# Altium schematic sheet CAN Interface.SchDoc
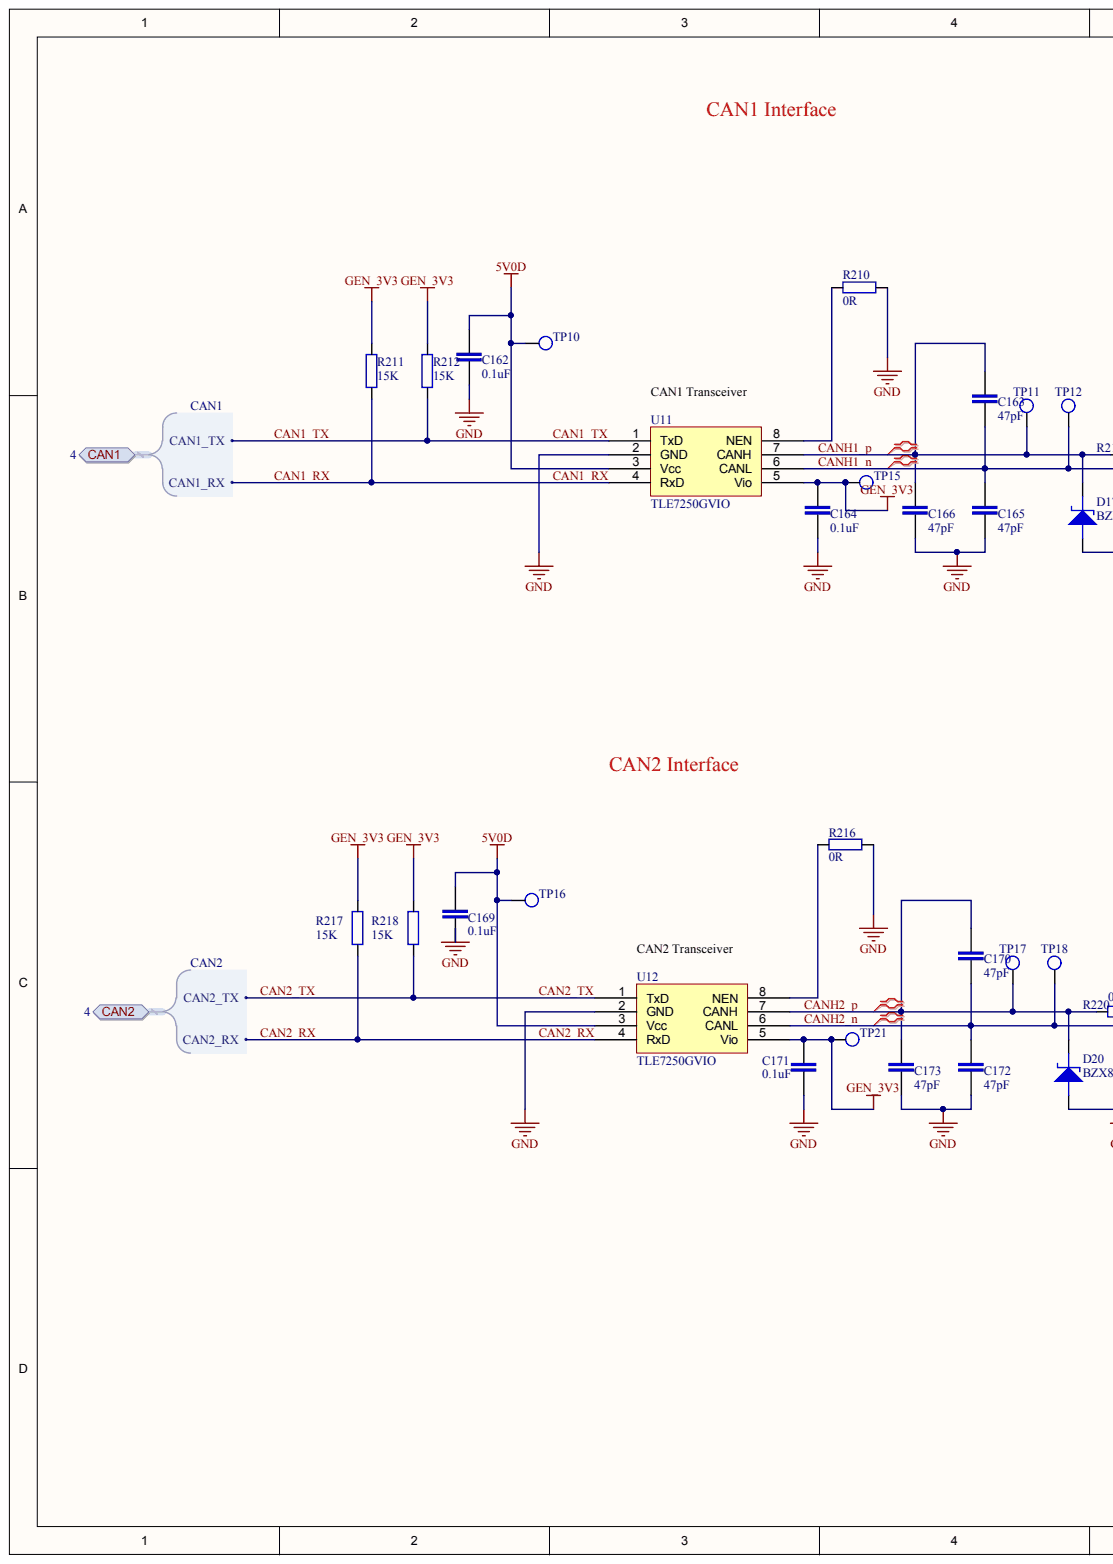
[diagram p0: CAN Interface.SchDoc, left]
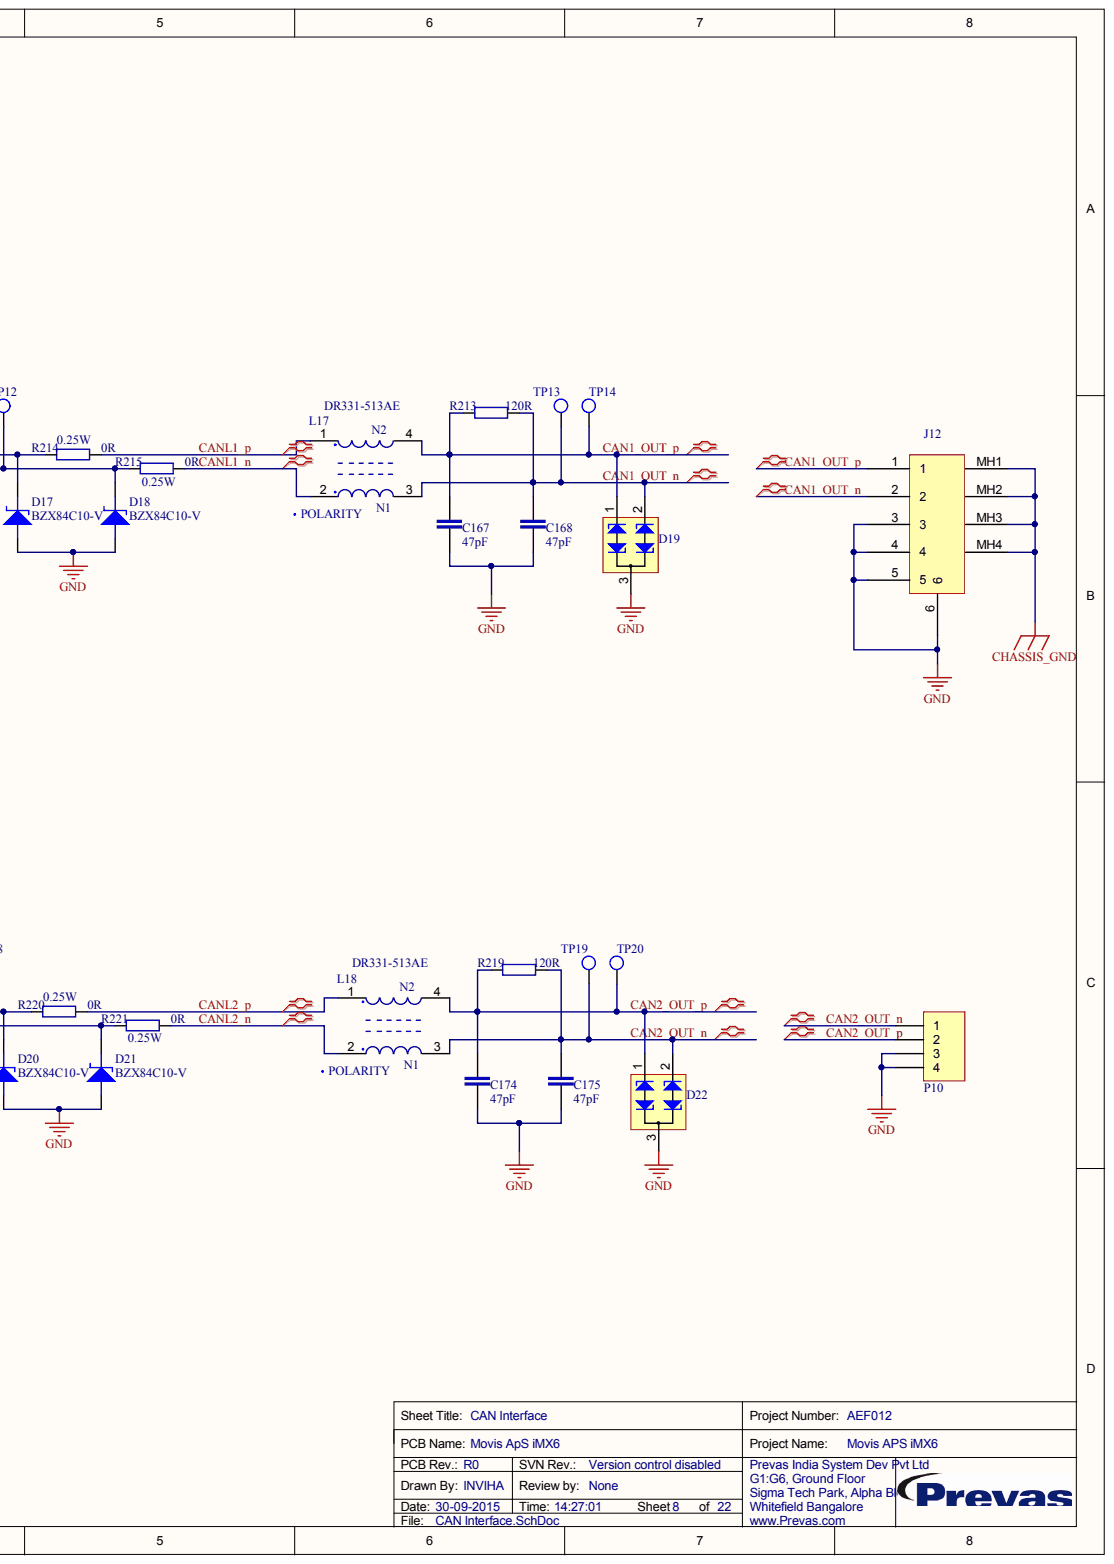
[diagram p1: CAN Interface.SchDoc, right]

## Parts
C162  0.1uF  [CAPC1005X55N]
C163  47pF  [CAPC1005X55N]
C164  0.1uF  [CAPC1005X55N]
C165  47pF  [CAPC1005X55N]
C166  47pF  [CAPC1005X55N]
C167  47pF  [CAPC1005X55N]
C168  47pF  [CAPC1005X55N]
C169  0.1uF  [CAPC1005X55N]
C170  47pF  [CAPC1005X55N]
C171  0.1uF  [CAPC1005X55N]
C172  47pF  [CAPC1005X55N]
C173  47pF  [CAPC1005X55N]
C174  47pF  [CAPC1005X55N]
C175  47pF  [CAPC1005X55N]
D17  BZX84C10-V  [SOT95P240X110-3N]
D18  BZX84C10-V  [SOT95P240X110-3N]
D19  PESD2CAN  [SOT95P240X110-3N]  (value not drawn)
D20  BZX84C10-V  [SOT95P240X110-3N]
D21  BZX84C10-V  [SOT95P240X110-3N]
D22  PESD2CAN  [SOT95P240X110-3N]  (value not drawn)
J12  99-3441-202-05  [CON5RA_M12A]  (value not drawn)
L17  DR331-513AE  [IND_DR331]
L18  DR331-513AE  [IND_DR331]
P10  533980471  [MOLEX_53398-4]  (value not drawn)
R210  0R  [RESC1608X55N]
R211  15K  [RESC1608X55N]
R212  15K  [RESC1608X55N]
R213  120R  [RESC1608X55N]
R214  0R  elec_power_rating: 0.25W  [RESC3216X65N]
R215  0R  elec_power_rating: 0.25W  [RESC3216X65N]
R216  0R  [RESC1608X55N]
R217  15K  [RESC1608X55N]
R218  15K  [RESC1608X55N]
R219  120R  [RESC1608X55N]
R220  0R  elec_power_rating: 0.25W  [RESC3216X65N]
R221  0R  elec_power_rating: 0.25W  [RESC3216X65N]
TP10  Testpoint  [TP_SMD]  (value not drawn)  (no BOM)
TP11  Testpoint  [TP_SMD]  (value not drawn)  (no BOM)
TP12  Testpoint  [TP_SMD]  (value not drawn)  (no BOM)
TP13  Testpoint  [TP_SMD]  (value not drawn)  (no BOM)
TP14  Testpoint  [TP_SMD]  (value not drawn)  (no BOM)
TP15  Testpoint  [TP_SMD]  (value not drawn)  (no BOM)
TP16  Testpoint  [TP_SMD]  (value not drawn)  (no BOM)
TP17  Testpoint  [TP_SMD]  (value not drawn)  (no BOM)
TP18  Testpoint  [TP_SMD]  (value not drawn)  (no BOM)
TP19  Testpoint  [TP_SMD]  (value not drawn)  (no BOM)
TP20  Testpoint  [TP_SMD]  (value not drawn)  (no BOM)
TP21  Testpoint  [TP_SMD]  (value not drawn)  (no BOM)
U11  TLE7250GVIO  [SON127P400X500X145-8N]
U12  TLE7250GVIO  [SON127P400X500X145-8N]

## Ports
CAN1, CAN1.CAN1_RX, CAN1.CAN1_TX, CAN2, CAN2.CAN2_RX, CAN2.CAN2_TX

## Nets
5V0D: C162.2 C169.2 TP10.1 TP16.1 U11.3(Vcc) U12.3(Vcc)
CHASSIS_GND: J12.MH1 J12.MH2 J12.MH3 J12.MH4
GEN_3V3: C164.2 C171.2 R211.2 R212.2 R217.2 R218.2 TP15.1 TP21.1 U11.5(Vio) U12.5(Vio)
GND: C162.1 C164.1 C165.1 C166.1 C167.1 C168.1 C169.1 C171.1 C172.1 C173.1 C174.1 C175.1 D17.1 D18.1 D19.3 D20.1 D21.1 D22.3 J12.3 J12.4 J12.5 J12.6 P10.3 P10.4 R210.2 R216.2 U11.2(GND) U12.2(GND)
CAN1_OUT_N: C168.2 D19.2 J12.2 L17.3 R213.2 TP13.1
CAN1_OUT_P: C167.2 D19.1 J12.1 L17.4 R213.1 TP14.1
CAN1_RX: R211.1 U11.4(RxD) ; port CAN1
CAN1_TX: R212.1 U11.1(TxD) ; port CAN1
CAN2_OUT_N: C175.2 D22.2 L18.3 P10.1 R219.2 TP19.1
CAN2_OUT_P: C174.2 D22.1 L18.4 P10.2 R219.1 TP20.1
CAN2_RX: R217.1 U12.4(RxD) ; port CAN2
CAN2_TX: R218.1 U12.1(TxD) ; port CAN2
CANH1_N: C163.1 C165.2 D18.3 R215.1 TP12.1 U11.6(CANL)
CANH1_P: C163.2 C166.2 D17.3 R214.1 TP11.1 U11.7(CANH)
CANH2_N: C170.1 C172.2 D21.3 R221.1 TP18.1 U12.6(CANL)
CANH2_P: C170.2 C173.2 D20.3 R220.1 TP17.1 U12.7(CANH)
CANL1_N: L17.2 R215.2
CANL1_P: L17.1 R214.2
CANL2_N: L18.2 R221.2
CANL2_P: L18.1 R220.2
NetR210_1: R210.1 U11.8(NEN)
NetR216_1: R216.1 U12.8(NEN)

## Library data of the parts
C162 C164 C169 C171 = INNISI-73: CAP CER 0.1UF 50V 10% X5R 0402; ref_part_type: /capacitor/non_polarized/ceramic/; source_manufacturer_1: TDK Corporation; source_manufacturer_1_pn: C1005X5R1H104K050BB; cae_altium_pcb_status: Prototype; Database Table Name: altium_default; db_rev: 7569; elec_temp_range: -55 to +85 C; phy_height: 0.55mm; phy_mounting: SMD; phy_packaging_type: 0402; ref_datasheet: INNISI-71; cae_altium_sch_status: Prototype; Component Kind: Standard; ComponentLink1Description: Datasheet; ComponentLink1URL: https://cop201.prevas.dk/viewvc/datasheet/INNISI-71.pdf?root=hw_components&view=co; db_created: 2015-08-03 03:34:59.0; db_last_updated: 03-08-2015 03:35:01; db_local_id: INNISI-73; db_status: Prototype; elec_tolerance: ±10%; elec_value: 0.1uF; elec_voltage_rating: 50V; phy_material: X5R; ref_keyword: CAP CER 0.1UF 50V 10% X5R 0402; Library Name: altium_mysql.dblib
C163 C165-C168 C170 C172-C175 = INNISI-81: CAP CER 47PF 50V 5% NPO 0402; ref_part_type: /capacitor/non_polarized/ceramic/; source_manufacturer_1: Yageo; source_manufacturer_1_pn: CC0402JRNPO9BN470; cae_altium_pcb_status: Prototype; Database Table Name: altium_default; db_rev: 7578; elec_temp_range: -55 to +125C; phy_height: 0.55mm; phy_material: C0G,NPO; phy_mounting: SMD; phy_packaging_type: 0402; ref_datasheet: INNISI-72; ref_keyword: Ceramic capacitor; cae_altium_sch_status: Prototype; Component Kind: Standard; ComponentLink1Description: Datasheet; ComponentLink1URL: https://cop201.prevas.dk/viewvc/datasheet/INNISI-72.pdf?root=hw_components&view=co; db_created: 2015-08-03 05:47:45.0; db_last_updated: 03-08-2015 05:47:46; db_local_id: INNISI-81; db_status: Prototype; elec_tolerance: 5%; elec_value: 47pF; elec_voltage_rating: 50V; Library Name: altium_mysql.dblib
D17 D18 D20 D21 = JEPE-24: Zener Diode Small Signal SOT-23; ref_part_type: /diode/zener/; source_manufacturer_1: Vishay; cae_altium_pcb_status: Prototype; Database Table Name: altium_default; db_rev: 5055; elec_power_rating: 300mW; elec_temp_range: -65 to +150; elec_tolerance: 5%; elec_voltage_rating: 10V; phy_height: 1.15mm; phy_mounting: SMD; ref_datasheet: JEPE-16; ref_keyword: Zener Diode SMD; cae_altium_sch_status: Prototype; Component Kind: Standard; ComponentLink1Description: Datasheet; ComponentLink1URL: https://cop201.prevas.dk/viewvc/datasheet/JEPE-16.pdf?root=hw_components&view=co; db_created: 2008-05-28 14:29:00; db_last_updated: 8/4/2012 1:07:00 AM; db_local_id: JEPE-24; db_status: Prototype; elec_value: BZX84C10-V; phy_packaging_type: SOT-23; source_manufacturer_1_pn: BZX84C10-V; Library Name: altium_mysql.dblib
D19 D22 = MASD-19: CAN bus ESD protection diode; ref_part_type: /diode/transient/; source_manufacturer_1: NXP; cae_altium_pcb_status: Prototype; Database Table Name: altium_default; db_rev: 1621; elec_current_rating: 5A; elec_temp_range: -65 to 150; elec_voltage_rating: 24V; phy_height: 1.1mm; phy_mounting: SMD; phy_packaging_type: SOT23; ref_datasheet: MASD-18; cae_altium_sch_status: Prototype; Component Kind: Standard; ComponentLink1Description: Datasheet; ComponentLink1URL: https://cop201.prevas.dk/viewvc/datasheet/MASD-18.pdf?root=hw_components&view=co; db_created: 2008-11-05 13:42:25; db_last_updated: 8/25/2009 11:45:10 AM; db_local_id: MASD-19; db_status: Prototype; elec_value: PESD2CAN; ref_keyword: PESD2CAN; source_manufacturer_1_pn: PESD2CAN; Library Name: altium_mysql.dblib
J12 = INNISI-63: Male receptacle, PCB mounting, 90° PCB solder; ref_part_type: /connector/5 pins/; source_manufacturer_1: Binder; cae_altium_pcb_status: Prototype; Database Table Name: altium_default; db_rev: 7472; elec_current_rating: 4A; elec_temp_range: -40C to +85C; elec_value: 99 3441 202 05; phy_mounting: Leaded; ref_datasheet: INNISI-62; ref_keyword: PCB Mount 5 pin connector; cae_altium_sch_status: Prototype; Component Kind: Standard; ComponentLink1Description: Datasheet; ComponentLink1URL: https://cop201.prevas.dk/viewvc/datasheet/INNISI-62.pdf?root=hw_components&view=co; db_created: 2015-06-18 07:05:21.0; db_last_updated: 19-06-2015 04:03:14; db_local_id: INNISI-63; db_status: Prototype; source_manufacturer_1_pn: 99 3441 202 05; Library Name: altium_mysql.dblib
L17 L18 = INRAAN-17: DR331 Series Surface Mount Data Line Chokes; ref_part_type: /inductor/signal/; source_manufacturer_1: BOURNS; cae_altium_pcb_status: Prototype; Database Table Name: altium_default; db_rev: 6078; elec_current_rating: 0.5A; elec_temp_range: -40 to 135; elec_value: 2uH; elec_voltage_rating: 80V DC; phy_height: 5; phy_mounting: SMD; ref_datasheet: INRAAN-19; ref_keyword: choke; cae_altium_sch_status: Prototype; Component Kind: Standard; ComponentLink1Description: Datasheet; ComponentLink1URL: https://cop201.prevas.dk/viewvc/datasheet/INRAAN-19.pdf?root=hw_components&view=co; db_created: 2013-11-13 06:07:52.0; db_last_updated: 12/2/2013 10:31:09 AM; db_local_id: INRAAN-17; db_status: Prototype; source_manufacturer_1_pn: DR331-513AE; Library Name: altium_mysql.dblib
P10 = MITO-29: PicoBlade header 4-pin; ref_part_type: /connector/4 pins/; source_manufacturer_1: Molex; cae_altium_pcb_status: Prototype; Database Table Name: altium_default; db_rev: 591; elec_current_rating: 1A; elec_temp_range: -40 to +85°C; elec_voltage_rating: 125V; phy_height: 4.7mm; phy_material: High temperature thermoplastic; phy_mounting: SMD; phy_packaging_type: Vertical; ref_datasheet: MITO-23; cae_altium_sch_status: Prototype; Component Kind: Standard; ComponentLink1Description: Datasheet; ComponentLink1URL: https://cop201.prevas.dk/viewvc/datasheet/MITO-23.pdf?root=hw_components&view=co; db_created: 2008-10-14 14:05:56; db_last_updated: 20-11-2008 11:02:42; db_local_id: MITO-29; db_status: Prototype; elec_value: 533980471; ref_keyword: Header; source_manufacturer_1_pn: 533980471; Library Name: altium_mysql.dblib
R210 R216 = INVIHA-4: RES SMD 0.0 OHM JUMPER 1/10W; ref_part_type: /resistor/fixed/; source_manufacturer_1: Panasonic Electronic Components; source_manufacturer_1_pn: ERJ-3GEY0R00V; cae_altium_pcb_status: Prototype; Database Table Name: altium_default; db_rev: 7580; elec_power_rating: 0.1W; elec_temp_range: -55 to +155° C; phy_height: 0.55mm; phy_packaging_type: 0603; ref_datasheet: INVIHA-3; ref_keyword: Chip Resistor; cae_altium_sch_status: Prototype; Component Kind: Standard; ComponentLink1Description: Datasheet; ComponentLink1URL: https://cop201.prevas.dk/viewvc/datasheet/INVIHA-3.pdf?root=hw_components&view=co; db_created: 2015-08-03 05:59:19.0; db_last_updated: 03-08-2015 5:59:21 AM; db_local_id: INVIHA-4; db_status: Prototype; elec_value: 0R; phy_mounting: SMD; Library Name: altium_mysql.dblib
R211 R212 R217 R218 = INVIHA-23: RES SMD 15K OHM 1% 1/10W 0603; ref_part_type: /resistor/fixed/; source_manufacturer_1: Yageo; source_manufacturer_1_pn: RC0603FR-0715KL; cae_altium_pcb_status: Prototype; Database Table Name: altium_default; db_rev: 7613; elec_power_rating: 0.1W; elec_temp_range: -55 to +155° C; phy_height: 0.55mm; phy_packaging_type: 0603; ref_keyword: Chip Resistor; cae_altium_sch_status: Prototype; Component Kind: Standard; ComponentLink1Description: Datasheet; ComponentLink1URL: https://cop201.prevas.dk/viewvc/datasheet/INVIHA-2.pdf?root=hw_components&view=co; db_created: 2015-08-03 08:11:15.0; db_last_updated: 03-08-2015 8:11:17 AM; db_local_id: INVIHA-23; db_status: Prototype; elec_tolerance: 1%; elec_value: 15K; phy_mounting: SMD; ref_datasheet: INVIHA-2; Library Name: altium_mysql.dblib
R213 R219 = INVIHA-24: RES SMD 120 OHM 1% 1/10W 0603; ref_part_type: /resistor/fixed/; source_manufacturer_1: Yageo; source_manufacturer_1_pn: RC0603FR-07120RL; cae_altium_pcb_status: Prototype; Database Table Name: altium_default; db_rev: 7614; elec_power_rating: 0.1W; elec_temp_range: -55 to +155° C; phy_height: 0.55mm; phy_packaging_type: 0603; ref_keyword: Chip Resistor; cae_altium_sch_status: Prototype; Component Kind: Standard; ComponentLink1Description: Datasheet; ComponentLink1URL: https://cop201.prevas.dk/viewvc/datasheet/INVIHA-2.pdf?root=hw_components&view=co; db_created: 2015-08-03 08:15:00.0; db_last_updated: 03-08-2015 8:15:02 AM; db_local_id: INVIHA-24; db_status: Prototype; elec_tolerance: 1%; elec_value: 120R; phy_mounting: SMD; ref_datasheet: INVIHA-2; Library Name: altium_mysql.dblib
R214 R215 R220 R221 = SOMO-30: Resistor 1206 General Purpose; ref_part_type: /resistor/fixed/; source_manufacturer_1: Phycomp; source_manufacturer_1_pn: RC1206JR-**0R; cae_altium_pcb_status: Prototype; Database Table Name: altium_default; db_rev: 3087; elec_current_rating: 2A; elec_temp_range: -55 to +155; elec_voltage_rating: 200V; phy_height: 0.65mm; phy_material: Thick Film; phy_mounting: SMD; phy_packaging_type: 1206; ref_datasheet: JEPE-90; ref_keyword: Thick Film Chip Resistor; cae_altium_sch_status: Prototype; Component Kind: Standard; ComponentLink1Description: Datasheet; ComponentLink1URL: https://cop201.prevas.dk/viewvc/datasheet/JEPE-90.pdf?root=hw_components&view=co; db_created: 2011-01-06 16:09:25.0; db_last_updated: 1/6/2011 4:09:25 PM; db_local_id: SOMO-30; db_status: Prototype; elec_value: 0R; Library Name: altium_mysql.dblib
TP10-TP21 = JEPE-114: Test Point SMD; ref_part_type: /pcb_item/; cae_altium_pcb_status: Prototype; Database Table Name: altium_default; db_rev: 3281; cae_altium_sch_status: Prototype; ComponentLink1Description: Datasheet; db_created: 2008-08-18 11:39:42; db_last_updated: 3/23/2011 2:14:06 PM; db_local_id: JEPE-114; db_status: Prototype; elec_value: Test Point; phy_mounting: SMD; ref_keyword: Test  Point; Library Name: altium_mysql.dblib
U11 U12 = INRAAN-156: CAN Interface IC Transceiver w/o wake up 1Mbit; ref_part_type: /integrated/digital/interface/can/; source_manufacturer_1: Infineon Technologies; cae_altium_pcb_status: Prototype; Database Table Name: altium_default; db_rev: 6634; elec_temp_range: -40C to 150C; elec_voltage_rating: 4.75 V ~ 5.25 V; phy_height: 1.45mm; phy_mounting: SMD; phy_packaging_type: SO-8; ref_datasheet: INRAAN-157; cae_altium_sch_status: Prototype; Component Kind: Standard; ComponentLink1Description: Datasheet; ComponentLink1URL: https://cop201.prevas.dk/viewvc/datasheet/INRAAN-157.pdf?root=hw_components&view=co; db_created: 2014-07-16 10:34:11.0; db_last_updated: 7/21/2014 9:01:21 AM; db_local_id: INRAAN-156; db_status: Prototype; elec_value: TLE7250GVIO; ref_keyword: CAN Interface IC; source_manufacturer_1_pn: TLE7250GVIO; Library Name: altium_mysql.dblib
